# Altium schematic sheet main.SchDoc(main) (continued)
## Library data of the parts (continued)
U8 = ADS112C04IPWR: 16-bit, 2-kSPS, 4-ch, low-power, small-size delta-sigma ADC with PGA, VREF, 2x IDACs & I2C interface 16-TSSOP -40 to...; Manufacturer: Texas Instruments; Manufacturer Lifecycle Status: ACTIVE; Number of Channels: 4; Case/Package: TSSOP; Category: Analog to Digital Converters (ADCs); ClassName: HV_ADC; Component Kind: Standard; ComponentLink1Description: https://sigma.octopart.com:443/189718192/technical_drawing/Texas-Instruments-ADS112C04IPWR.pdf?src-supplier=Texas+Instruments; ComponentLink1URL: https://sigma.octopart.com:443/189718192/technical_drawing/Texas-Instruments-ADS112C04IPWR.pdf?src-supplier=Texas+Instruments; ComponentLink2Description: https://octopart.com:443/opatz8j6/c1?t=1qIBjjZ7iDcKuKdhAxJa0sU84AmFItfxV_srtVCSs4KQT-IlWVGpBpVviaOX-P6fWw41Ks2GVjhTaf3XmMbGW2jr7MYZIx9GJOK0Z7sifHVkz9xo_W8GypucCx_yxQCvW79HYNpqCaiFiDe8aA4UiHp6felMogAFFpf1lqPicuiURqeQBvRqKo1nQ5q1mPopZqYXhx1YqOFgOGHdNS7sd4Q4o5ZzLe-FlyEizAO421JJkYDOAQauF4a5De1Q-87uYiYRk4Y16aKT6dXUZmrRPAiI-Fm6RHytj8sbItjVgtLq-ez5GlFxxHSUHYrhV672h27vntTfVtR5lxQJtWgmFhdUKEZSwQmF0BvJfcTZHodLVXqwaXPWv94LeBGXofB3jvH25nR_8A&src-supplier=Texas+Instruments; ComponentLink2URL: https://octopart.com:443/opatz8j6/c1?t=1qIBjjZ7iDcKuKdhAxJa0sU84AmFItfxV_srtVCSs4KQT-IlWVGpBpVviaOX-P6fWw41Ks2GVjhTaf3XmMbGW2jr7MYZIx9GJOK0Z7sifHVkz9xo_W8GypucCx_yxQCvW79HYNpqCaiFiDe8aA4UiHp6felMogAFFpf1lqPicuiURqeQBvRqKo1nQ5q1mPopZqYXhx1YqOFgOGHdNS7sd4Q4o5ZzLe-FlyEizAO421JJkYDOAQauF4a5De1Q-87uYiYRk4Y16aKT6dXUZmrRPAiI-Fm6RHytj8sbItjVgtLq-ez5GlFxxHSUHYrhV672h27vntTfVtR5lxQJtWgmFhdUKEZSwQmF0BvJfcTZHodLVXqwaXPWv94LeBGXofB3jvH25nR_8A&src-supplier=Texas+Instruments; ComponentLink3Description: https://sigma.octopart.com:443/116621398/technical_drawing/Texas-Instruments-ADS112C04IPWR.pdf?src-supplier=Texas+Instruments; ComponentLink3URL: https://sigma.octopart.com:443/116621398/technical_drawing/Texas-Instruments-ADS112C04IPWR.pdf?src-supplier=Texas+Instruments; ComponentLink4Description: https://sigma.octopart.com:443/49249815/technical_drawing/Texas-Instruments-ADS112C04IPWR.pdf?src-supplier=Texas+Instruments; ComponentLink4URL: https://sigma.octopart.com:443/49249815/technical_drawing/Texas-Instruments-ADS112C04IPWR.pdf?src-supplier=Texas+Instruments; ComponentLink5Description: https://datasheet.octopart.com:443/ADS112C04IPWR-Texas-Instruments-datasheet-164412421.pdf?src-supplier=SHENGYU+ELECTRONICS; ComponentLink5URL: https://datasheet.octopart.com:443/ADS112C04IPWR-Texas-Instruments-datasheet-164412421.pdf?src-supplier=SHENGYU+ELECTRONICS; ComponentLink6Description: http://sigma.octopart.com:80/conflict_mineral_statement/Texas-Instruments-company-63.pdf?src-supplier=Compliance+Statements; ComponentLink6URL: http://sigma.octopart.com:80/conflict_mineral_statement/Texas-Instruments-company-63.pdf?src-supplier=Compliance+Statements; ComponentLink7Description: http://sigma.octopart.com:80/reach_statement/Texas-Instruments-company-64.pdf?src-supplier=Compliance+Statements; ComponentLink7URL: http://sigma.octopart.com:80/reach_statement/Texas-Instruments-company-64.pdf?src-supplier=Compliance+Statements; Height: 1.2mm; Interface: I2C; Length: 5mm; Lifecycle Status: Production; Manufacturer Part Number: ADS112C04IPWR; Max Operating Temperature: 125°C; Min Operating Temperature: -40°C; Number of Inputs: 4; Pins: 16; Pricing: 1=7,16, 10=5,523, 25=5,1136, 100=4,664, 250=4,4496, 500=4,32034, 1000=4,21399 (USD); RoHS: Compliant; Stock: 2131; Supplier: DigiKey; Supplier Part Number: 296-50875-1-ND; Thickness: 1mm; Width: 4.4mm; Library Name: IMCODR Components.SchLib
